# Altium schematic sheet BtnCore.SchDoc
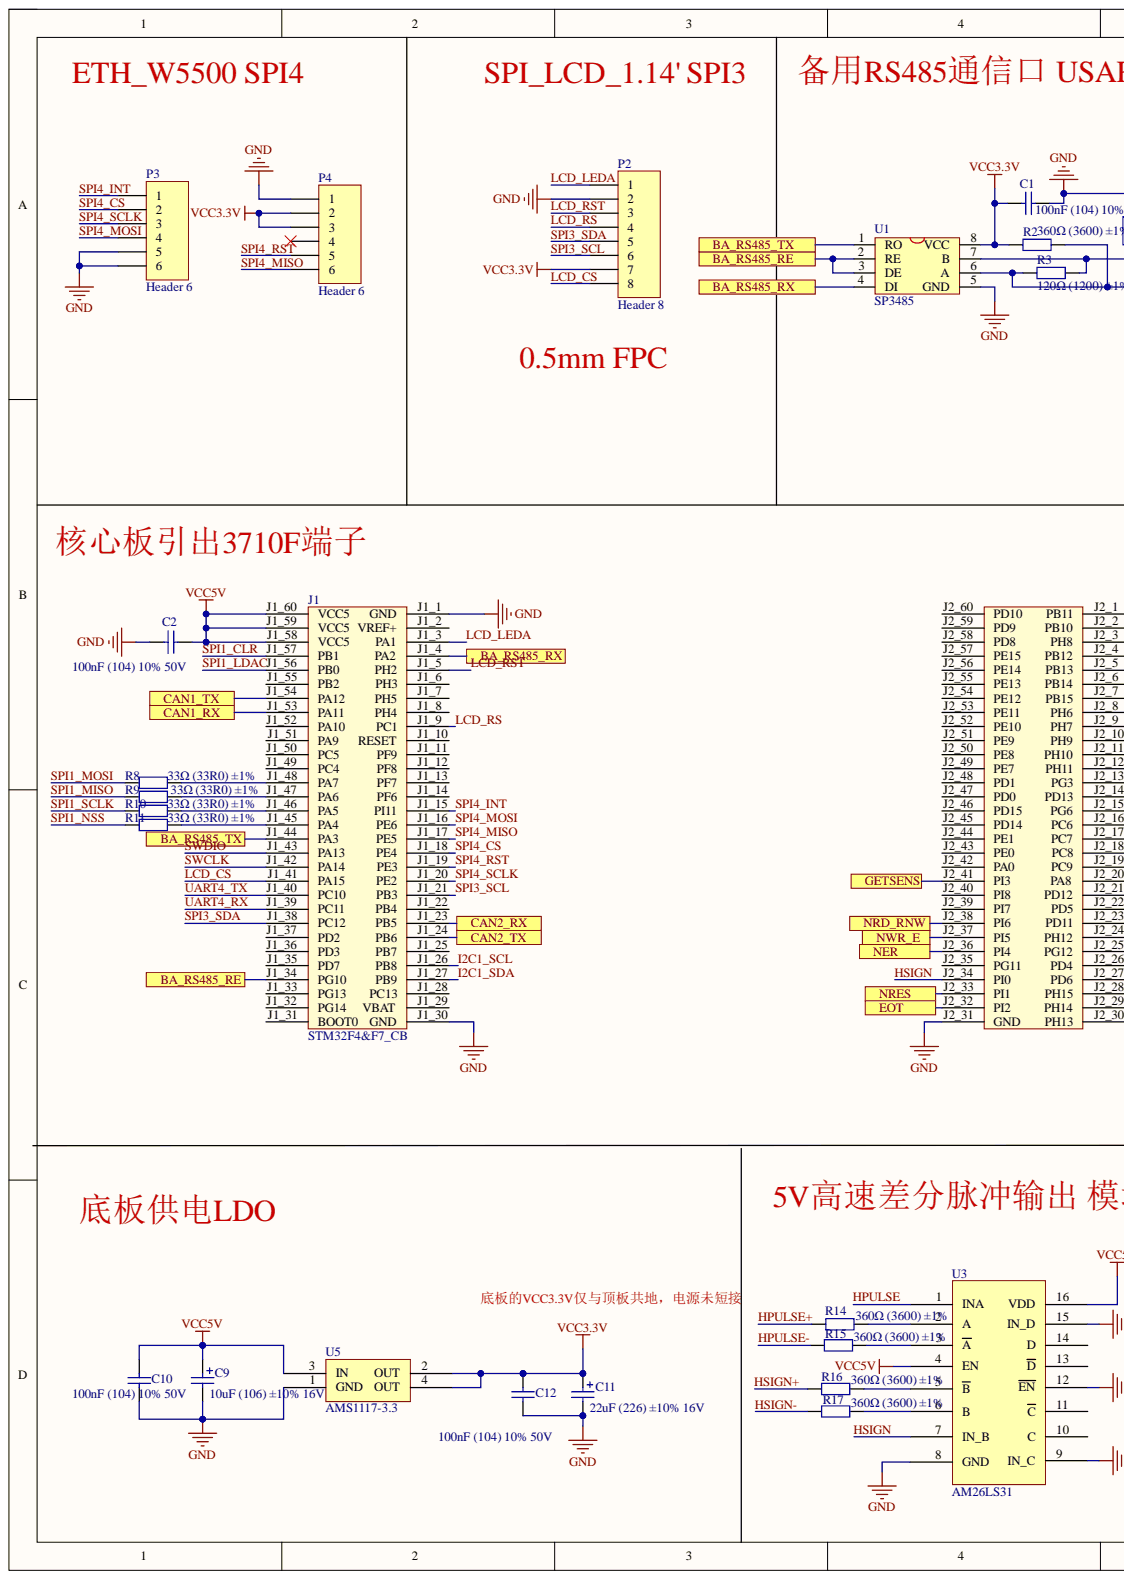
[diagram p0: BtnCore.SchDoc, left]
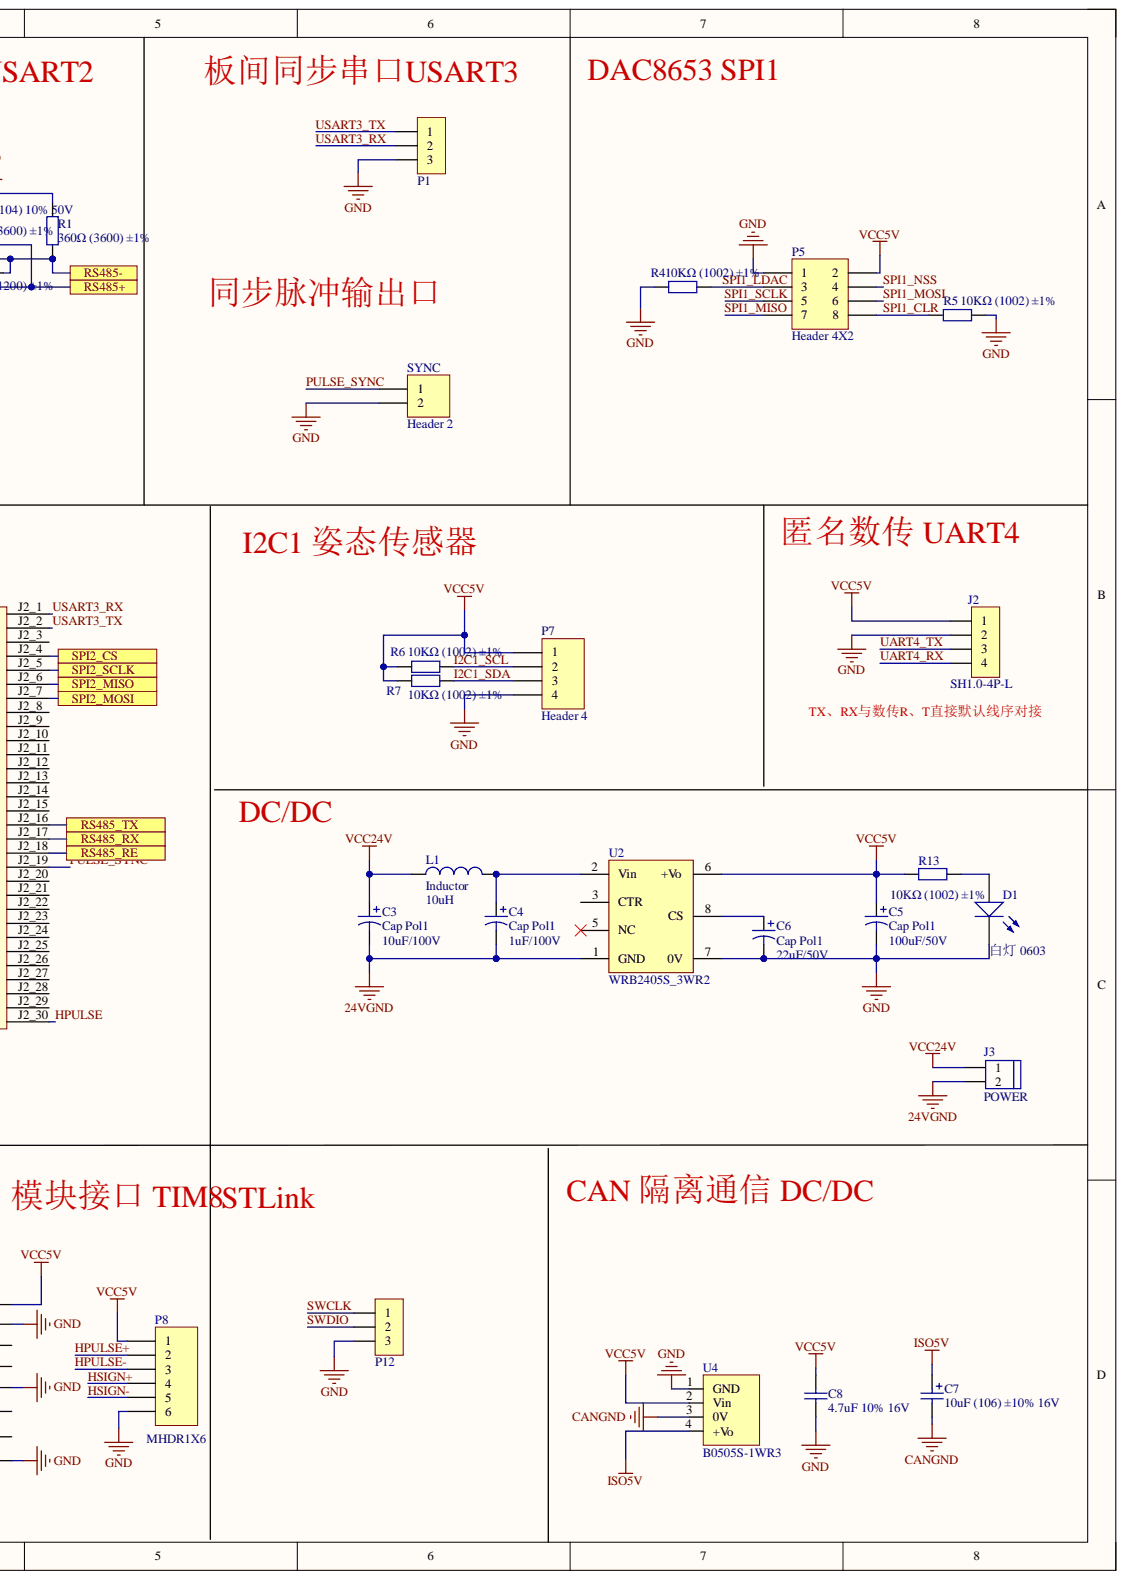
[diagram p1: BtnCore.SchDoc, right]

## Parts
C1  100nF (104) 10% 50V  [0402_C]
C2  100nF (104) 10% 50V  [0402_C]
C3  Cap Pol1  value 10uF/100V  [CAP-E8mm]
C4  Cap Pol1  value 1uF/100V  [CAP-E5mm]
C5  Cap Pol1  value 100uF/50V  [CAP-E8mm]
C6  Cap Pol1  value 22uF/50V  [CAP-E5mm]
C7  10uF (106) ±10% 16V  [CASE-A_3216]
C8  4.7uF 10% 16V  [0603_C]
C9  10uF (106) ±10% 16V  [CASE-A_3216]
C10  100nF (104) 10% 50V  [0402_C]
C11  22uF (226) ±10% 16V  [CASE-B_3528]
C12  100nF (104) 10% 50V  [0402_C]
D1  白灯 0603  Package: 0603  [0603_LED_S1]
J1  STM32F4&F7_CB  [STM32F4&F7_CB]
J2  SH1.0-4P-L  [SH1.0-4P-L]
J3  POWER  [HEAD2L-0.8]
L1  Inductor  value 10uH  [0603_L]
P1  SH1.0-3P  [SH1.0-3P-L]  (value not drawn)
P2  Header 8  [FPC0.5 2H-WS-8P]
P3  Header 6  [HDR1X6]
P4  Header 6  [HDR1X6]
P5  Header 4X2  [HDR2X4]
P7  Header 4  [SH1.0-4P-L]
P8  MHDR1X6  [SH1.0-6P-L]
P12  SH1.0-3P  [SH1.0-3P-L]  (value not drawn)
R1  360Ω (3600) ±1%  [0402_R]
R2  360Ω (3600) ±1%  [0402_R]
R3  120Ω (1200) ±1%  [0402_R]
R4  10KΩ (1002) ±1%  [0402_R]
R5  10KΩ (1002) ±1%  [0402_R]
R6  10KΩ (1002) ±1%  [0402_R]
R7  10KΩ (1002) ±1%  [0402_R]
R8  33Ω (33R0) ±1%  [0402_R]
R9  33Ω (33R0) ±1%  [0402_R]
R10  33Ω (33R0) ±1%  [0402_R]
R11  33Ω (33R0) ±1%  [0402_R]
R13  10KΩ (1002) ±1%  [0402_R]
R14  360Ω (3600) ±1%  [0402_R]
R15  360Ω (3600) ±1%  [0402_R]
R16  360Ω (3600) ±1%  [0402_R]
R17  360Ω (3600) ±1%  [0402_R]
SYNC  Header 2  [HDR1X2]
U1  SP3485  [SOP-8]
U2  WRB2405S_3WR2  [DCDC_SUCCEED-WRA_S/WRB-1/2/3W]
U3  AM26LS31  [SO-16_N]
U4  B0505S-1WR3  [B_S-1WR3]
U5  AMS1117-3.3  [SOT223_M]

## Ports
BA_RS485_RE, BA_RS485_RX, BA_RS485_TX, CAN1_RX, CAN1_TX, CAN2_RX, CAN2_TX, EOT, GETSENS, NER, NRD_RNW, NRES, NWR_E, RS485+, RS485-, RS485_RE, RS485_RX, RS485_TX, SPI2_CS, SPI2_MISO, SPI2_MOSI, SPI2_SCLK

## Nets
24VGND: C3.2 C4.2 J3.2 U2.1(GND)
CANGND: C7.2 U4.3(0V)
GND: C1.1 C2.1 C5.2 C6.2 C8.2 C9.2 C10.2 C11.2 C12.2 D1.K J1.J1_1 J1.J1_30 J1.J2_31 J2.2 P1.3 P2.2 P3.5 P3.6 P4.1 P5.1 P7.4 P8.6 P12.3 R1.2 R4.2 R5.1 SYNC.2 U1.5(GND) U2.7(0V) U3.8(GND) U3.9(IN_C) U3.12(EN) U3.15(IN_D) U4.1(GND) U5.1(GND)
ISO5V: C7.1 U4.4(+Vo)
VCC3.3V: C1.2 C11.1 C12.1 P2.7 P4.2 P4.3 R2.1 U1.8(VCC) U5.2(OUT) U5.4(OUT)
VCC5V: C2.2 C5.1 C8.1 C9.1 C10.1 J1.J1_58(VCC5) J1.J1_59(VCC5) J1.J1_60(VCC5) J2.1 P5.2 P7.1 P8.1 R6.1 R7.1 R13.1 U2.6(+Vo) U3.4(EN) U3.16(VDD) U4.2(Vin) U5.3(IN)
VCC24V: C3.1 J3.1 L1.1
BA_RS485_RE: J1.J1_34(PG10) U1.2(RE) U1.3(DE) ; port BA_RS485_RE
BA_RS485_RX: J1.J1_4 U1.4(DI) ; port BA_RS485_RX
BA_RS485_TX: J1.J1_44(PA3) U1.1(RO) ; port BA_RS485_TX
CAN1_RX: J1.J1_53(PA11) ; port CAN1_RX
CAN1_TX: J1.J1_54(PA12) ; port CAN1_TX
CAN2_RX: J1.J1_23(CAN2_RX) ; port CAN2_RX
CAN2_TX: J1.J1_24(CAN2_TX) ; port CAN2_TX
EOT: J1.J2_32 ; port EOT
GETSENS: J1.J2_41(GETSENS) ; port GETSENS
HPULSE: J1.J2_30(PH13) U3.1(INA)
HPULSE+: P8.2 R14.2
HPULSE-: P8.3 R15.2
HSIGN: J1.J2_34 U3.7(IN_B)
HSIGN+: P8.4 R16.2
HSIGN-: P8.5 R17.2
I2C1_SCL: J1.J1_26 P7.2 R6.2
I2C1_SDA: J1.J1_27 P7.3 R7.2
LCD_CS: J1.J1_41(PA15) P2.8
LCD_LEDA: J1.J1_3 P2.1
LCD_RS: J1.J1_9 P2.4
LCD_RST: J1.J1_5 P2.3
NER: J1.J2_36 ; port NER
NRD_RNW: J1.J2_38(NRD_RNW) ; port NRD_RNW
NRES: J1.J2_33 ; port NRES
NWR_E: J1.J2_37(NWR_E) ; port NWR_E
PULSE_SYNC: J1.J2_19(PC9) SYNC.1
RS485_RE: J1.J2_18(PC8) ; port RS485_RE
RS485_RX: J1.J2_17(PC7) ; port RS485_RX
RS485_TX: J1.J2_16(PC6) ; port RS485_TX
SPI1_CLR: J1.J1_57(PB1) P5.8 R5.2
SPI1_LDAC: J1.J1_56(PB0) P5.3 R4.1
SPI1_MISO: P5.7 R9.1
SPI1_MOSI: P5.6 R8.1
SPI1_NSS: P5.4 R11.1
SPI1_SCLK: P5.5 R10.1
SPI2_CS: J1.J2_4(PB12) ; port SPI2_CS
SPI2_MISO: J1.J2_6(PB14) ; port SPI2_MISO
SPI2_MOSI: J1.J2_7(PB15) ; port SPI2_MOSI
SPI2_SCLK: J1.J2_5(PB13) ; port SPI2_SCLK
SPI3_SCL: J1.J1_21 P2.6
SPI3_SDA: J1.J1_38(PC12) P2.5
SPI4_CS: J1.J1_18 P3.2
SPI4_INT: J1.J1_15 P3.1
SPI4_MISO: J1.J1_17 P4.6
SPI4_MOSI: J1.J1_16 P3.4
SPI4_RST: J1.J1_19 P4.5
SPI4_SCLK: J1.J1_20 P3.3
SWCLK: J1.J1_42(PA14) P12.1
SWDIO: J1.J1_43(PA13) P12.2
UART4_RX: J1.J1_39(PC11) J2.4
UART4_TX: J1.J1_40(PC10) J2.3
USART3_RX: J1.J2_1(PB11) P1.2
USART3_TX: J1.J2_2(PB10) P1.1
NetC4_1: C4.1 L1.2 U2.2(Vin)
NetC6_1: C6.1 U2.8(CS)
NetD1_A: D1.A R13.2
NetJ1_J1_45: J1.J1_45(PA4) R11.2
NetJ1_J1_46: J1.J1_46(PA5) R10.2
NetJ1_J1_47: J1.J1_47(PA6) R9.2
NetJ1_J1_48: J1.J1_48(PA7) R8.2
NetR1_1: R1.1 R3.1 U1.7(B)
NetR2_2: R2.2 R3.2 U1.6(A)
NetR14_1: R14.1 U3.2(A)
NetR15_1: R15.1 U3.3(A)
NetR16_1: R16.1 U3.5(B)
NetR17_1: R17.1 U3.6(B)

Not connected: J1 J1_2 J1_6 J1_7 J1_8 J1_10 J1_11 J1_12 J1_13 J1_14 J1_22 J1_25 J1_28 J1_29 J1_31(BOOT0) J1_32(PG14) J1_33(PG13) J1_35(PD7) J1_36(PD3) J1_37(PD2) J1_49(PC4) J1_50(PC5) J1_51(PA9) J1_52(PA10) J1_55(PB2) J2_3(PH8) J2_8(PH6) J2_9(PH7) J2_10(PH9) J2_11(PH10) J2_12(PH11) J2_13(PG3) J2_14(PD13) J2_15(PG6) J2_20(PA8) J2_21(PD12) J2_22(PD5) J2_23(PD11) J2_24(PH12) J2_25(PG12) J2_26(PD4) J2_27(PD6) J2_28(PH15) J2_29(PH14) J2_35 J2_39 J2_40 J2_42 J2_43 J2_44 J2_45 J2_46 J2_47 J2_48 J2_49 J2_50 J2_51 J2_52 J2_53 J2_54 J2_55 J2_56 J2_57 J2_58 J2_59 J2_60; P4 4; U2 3(CTR) 5(NC); U3 10(C) 11(C) 13(D) 14(D)

## Library data of the parts
C1 C2 C8 C10 C12 = 0603 100nF (104) 10% 50V: 贴片电容; Component Kind: Standard; ComponentLink1Description: 供应商链接; ComponentLink1URL: http://www.szlcsc.com/product/details_15331.html; Library Name: Cap.SchLib; Package: 0603; Supplier: LC; SuppliersPartNumber: C14663
C3-C6 = Cap Pol1: Polarized Capacitor (Radial); Component Kind: Standard; LatestRevisionDate: 17-Jul-2002; LatestRevisionNote: Re-released for DXP Platform.; Library Name: Miscellaneous Devices.IntLib; PackageDescription: Polarized Capacitor (Radial); 2 Leads; PackageReference: RB7.6-15; Published: 8-Jun-2000; Signal Integrity: Cap Pol; Simulation: CAP; Publisher: Altium Limited
C7 C9 C11 = 3216 10uF (106) 10% 16V: 钽电容; Component Kind: Standard; ComponentLink1Description: 供应商链接; ComponentLink1URL: http://www.szlcsc.com/product/details_7643.html; Package: 3216; Supplier: LC; SuppliersPartNumber: C7171
  C7: Library Name: Miscellaneous Devices LC.IntLib
  C9 C11: Library Name: Cap.SchLib
D1 = 0603 白灯: 发光二极管; Component Kind: Standard; ComponentLink1Description: 供应商链接; ComponentLink1URL: http://www.szlcsc.com/product/details_2663.html; Library Name: LED.SchLib; Supplier: LC; SuppliersPartNumber: C2290
J1 = STM32F4&F7_CB: F429/746 Core Board; Component Kind: Standard; Library Name: STM32F429_F746.SCHLIB
J2 = Header 4: 4P接插件; 厂商: JST; BOM名称: 4P接插件; Component Kind: Standard; Library Name: 4.14接插件-脚距1.0.SchLib
J3 = HEAD2: Component Kind: Standard; Library Name: STM32F429_F746.SCHLIB
L1 = Inductor: Code_IEC: 1005; Code_JEITA: 0402; Component Kind: Standard; Description: Inductor; LatestRevisionDate: 29-May-2009; LatestRevisionNote: IPC-7351 Footprint Added.; Library Name: Miscellaneous Devices.IntLib; PackageDescription: Chip Inductor; PackageReference: 0402-A; Published: 8-Jun-2000; Signal Integrity: Inductor; Simulation: INDUCTOR; Publisher: Altium Limited
P1 P12 = Header 3: 3P接插件; 厂商: JST; BOM名称: 3P接插件; Component Kind: Standard; Library Name: 4.14接插件-脚距1.0.SchLib
P2 = Header 8: Header, 8-Pin; Component Kind: Standard; LatestRevisionDate: 17-Jul-2002; LatestRevisionNote: Re-released for DXP Platform.; Library Name: Miscellaneous Connectors.IntLib; Signal Integrity: Connector; Publisher: Altium Limited
P3 P4 = Header 6: Header, 6-Pin; Component Kind: Standard; LatestRevisionDate: 17-Jul-2002; LatestRevisionNote: Re-released for DXP Platform.; Library Name: Miscellaneous Connectors.IntLib; Signal Integrity: Connector; Publisher: Altium Limited
P5 = Header 4X2: Header, 4-Pin, Dual row; Component Kind: Standard; LatestRevisionDate: 17-Jul-2002; LatestRevisionNote: Re-released for DXP Platform.; Library Name: Miscellaneous Connectors.IntLib; Signal Integrity: Connector; Publisher: Altium Limited
P7 = Header 4: Header, 4-Pin; Component Kind: Standard; LatestRevisionDate: 17-Jul-2002; LatestRevisionNote: Re-released for DXP Platform.; Library Name: Miscellaneous Connectors.IntLib; Signal Integrity: Connector; Publisher: Altium Limited
P8 = MHDR1X6: Header, 6-Pin; Component Kind: Standard; LatestRevisionDate: 17-Jul-2002; LatestRevisionNote: Re-released for DXP Platform.; Library Name: Miscellaneous Connectors.IntLib; Signal Integrity: Connector; Publisher: Altium Limited
R1 R2 R14-R17 = 0603 360Ω (3600) 1%: 贴片电阻; Component Kind: Standard; ComponentLink1Description: 供应商链接; ComponentLink1URL: http://www.szlcsc.com/product/details_25937.html; Library Name: RES.SchLib; Package: 0603; Supplier: LC; SuppliersPartNumber: C25194
R3 = 0603 120Ω (1200) 1%: 贴片电阻; Component Kind: Standard; ComponentLink1Description: 供应商链接; ComponentLink1URL: http://www.szlcsc.com/product/details_23514.html; Library Name: RES.SchLib; Package: 0603; Supplier: LC; SuppliersPartNumber: C22787
R4-R7 R13 = 0805 100KΩ (1003) 1%: 贴片电阻; Component Kind: Standard; ComponentLink1Description: 供应商链接; ComponentLink1URL: http://www.szlcsc.com/product/details_18095.html; Library Name: RES.SchLib; Package: 0805; Supplier: LC; SuppliersPartNumber: C17407
R8-R11 = 0603 33Ω (33R0) 1%: 贴片电阻; Component Kind: Standard; ComponentLink1Description: 供应商链接; ComponentLink1URL: http://www.szlcsc.com/product/details_23867.html; Library Name: Miscellaneous Devices LC.IntLib; Package: 0603; Supplier: LC; SuppliersPartNumber: C23140
SYNC = Header 2: Header, 2-Pin; Component Kind: Standard; LatestRevisionDate: 17-Jul-2002; LatestRevisionNote: Re-released for DXP Platform.; Library Name: Miscellaneous Connectors.IntLib; Signal Integrity: Connector; Publisher: Altium Limited
U1 = MAX3485: Component Kind: Standard; Library Name: STM32F429_F746.SCHLIB
U2 = DCDC-WRB: 电源模块; Component Kind: Standard; Library Name: Library.SchLib
U3 = AM26LS31: AM32LS31 单端转差分芯片; Component Kind: Standard; Library Name: CAS.SchLib
U4 = B_S-1WR3: Component Kind: Standard; Library Name: B_S-1WR3.SchLib
U5 = AMS1117: 3.3V稳压芯片; Component Kind: Standard; Library Name: Library.SchLib
